# Altium schematic sheet be_mdv.SchDoc(be_mdv)
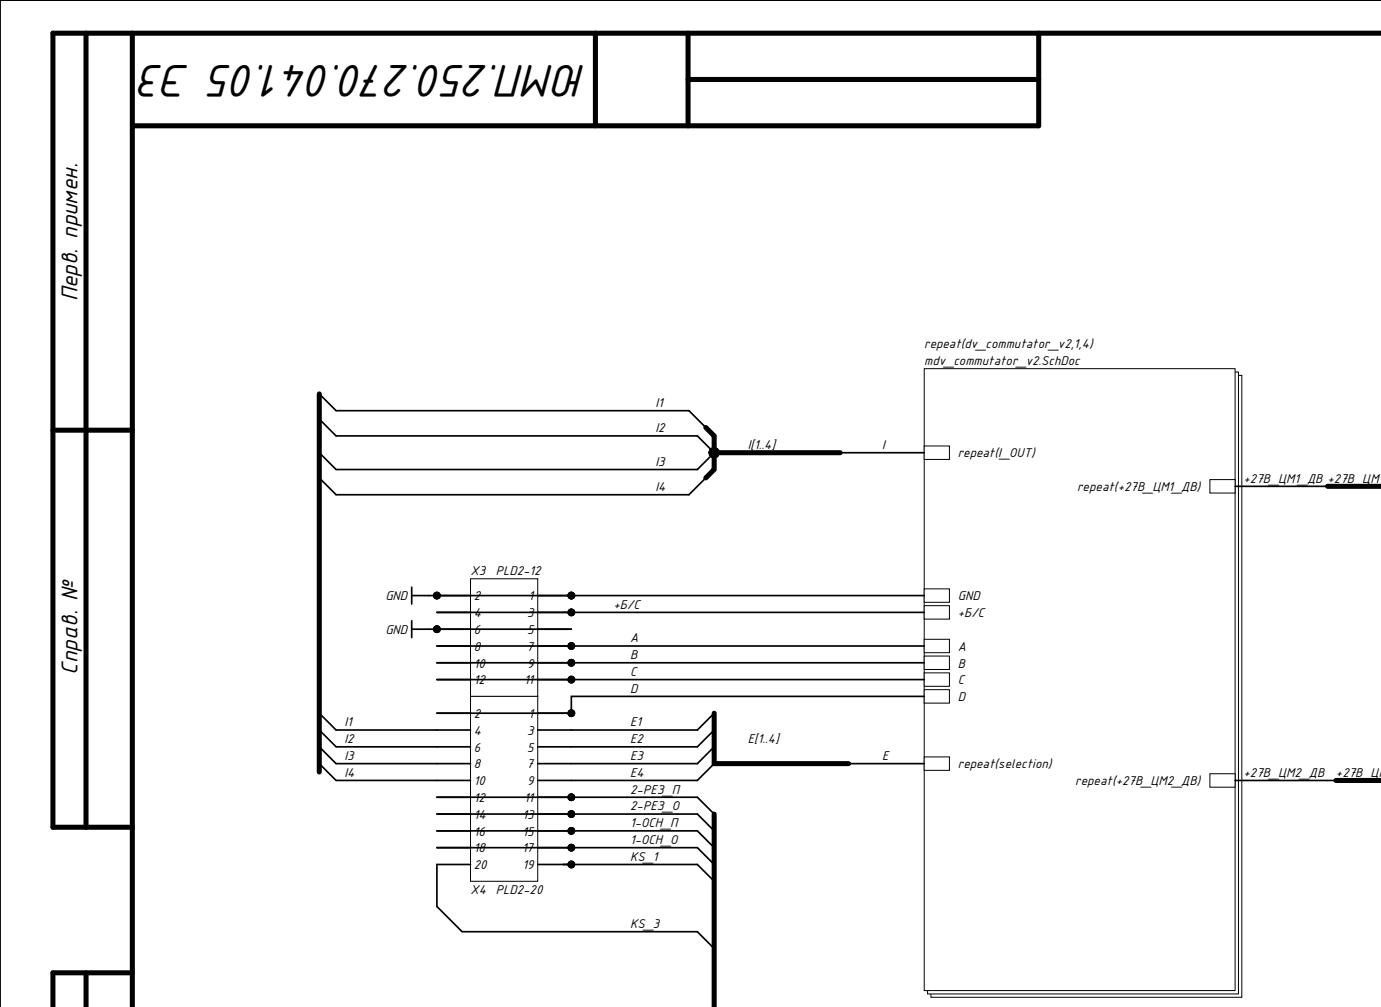
[diagram p0: be_mdv.SchDoc, top left]
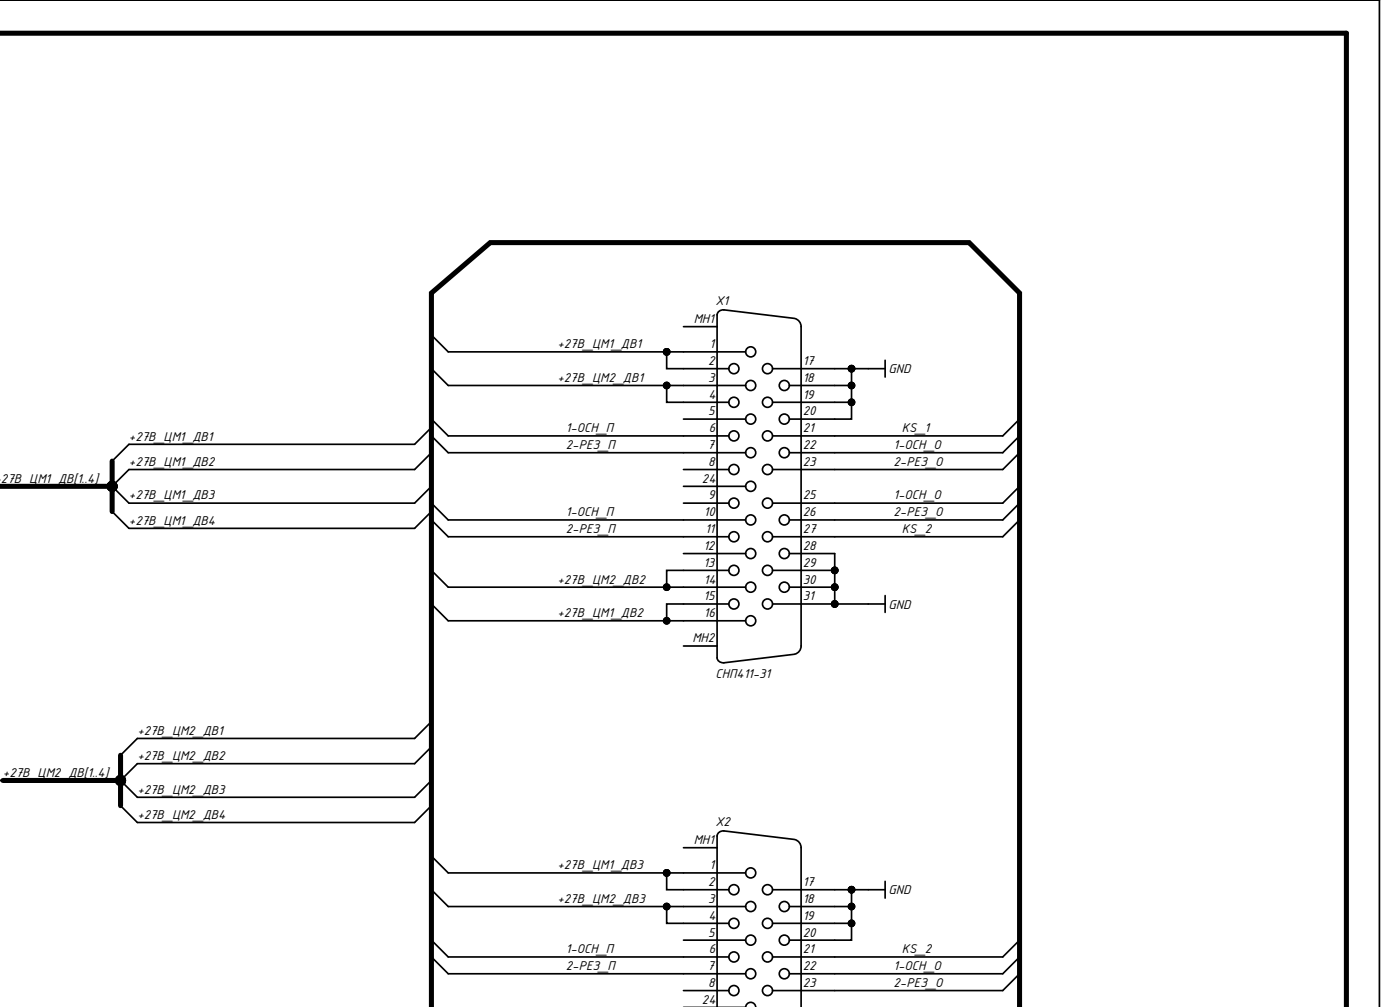
[diagram p1: be_mdv.SchDoc, top right]
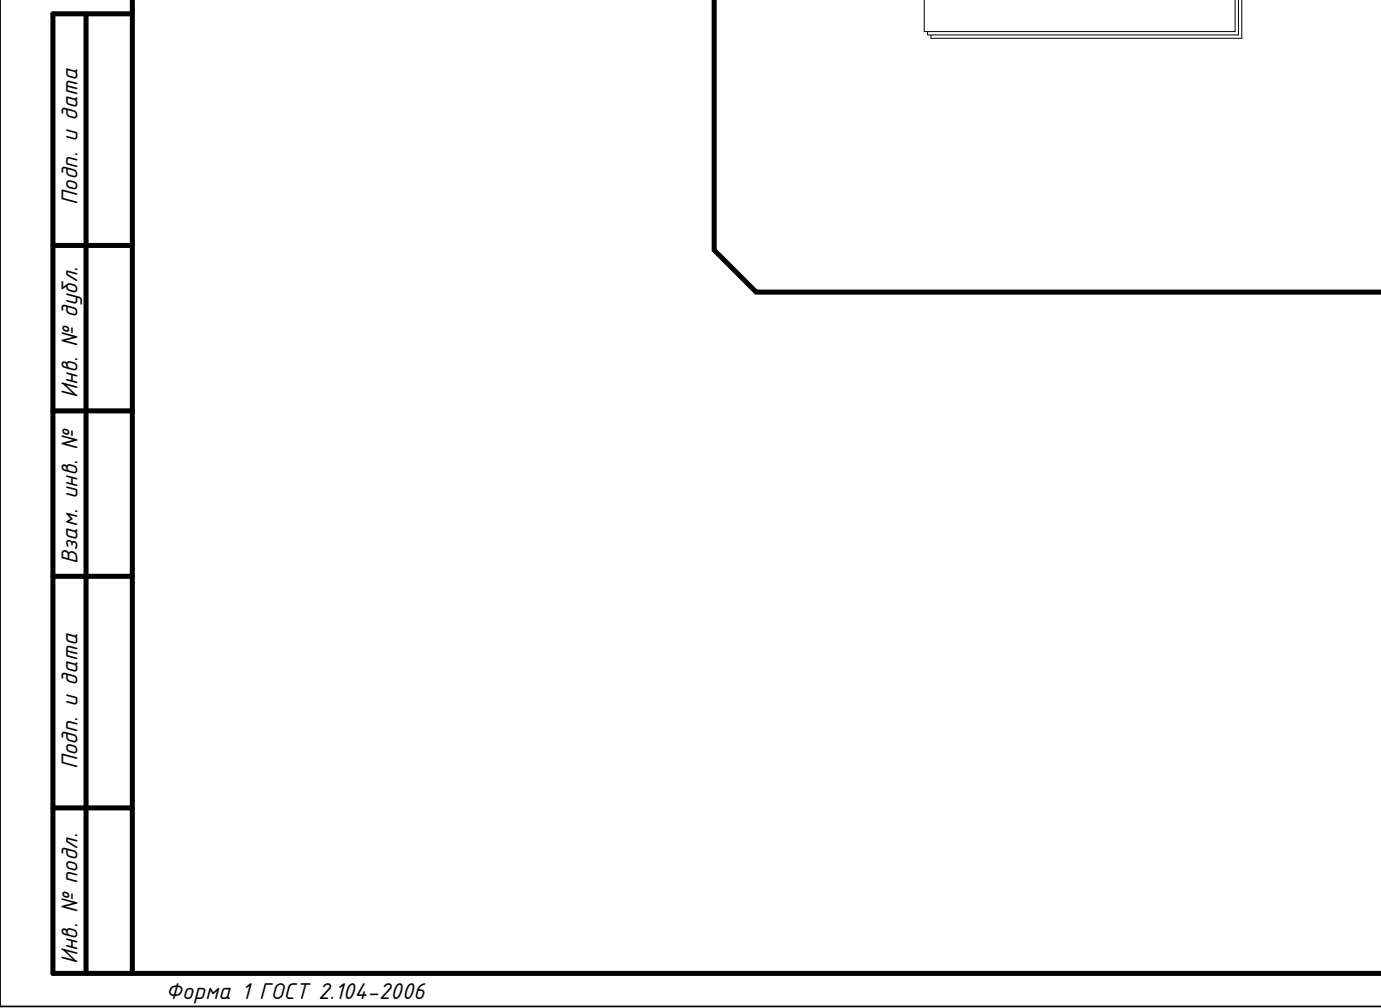
[diagram p2: be_mdv.SchDoc, bottom left]
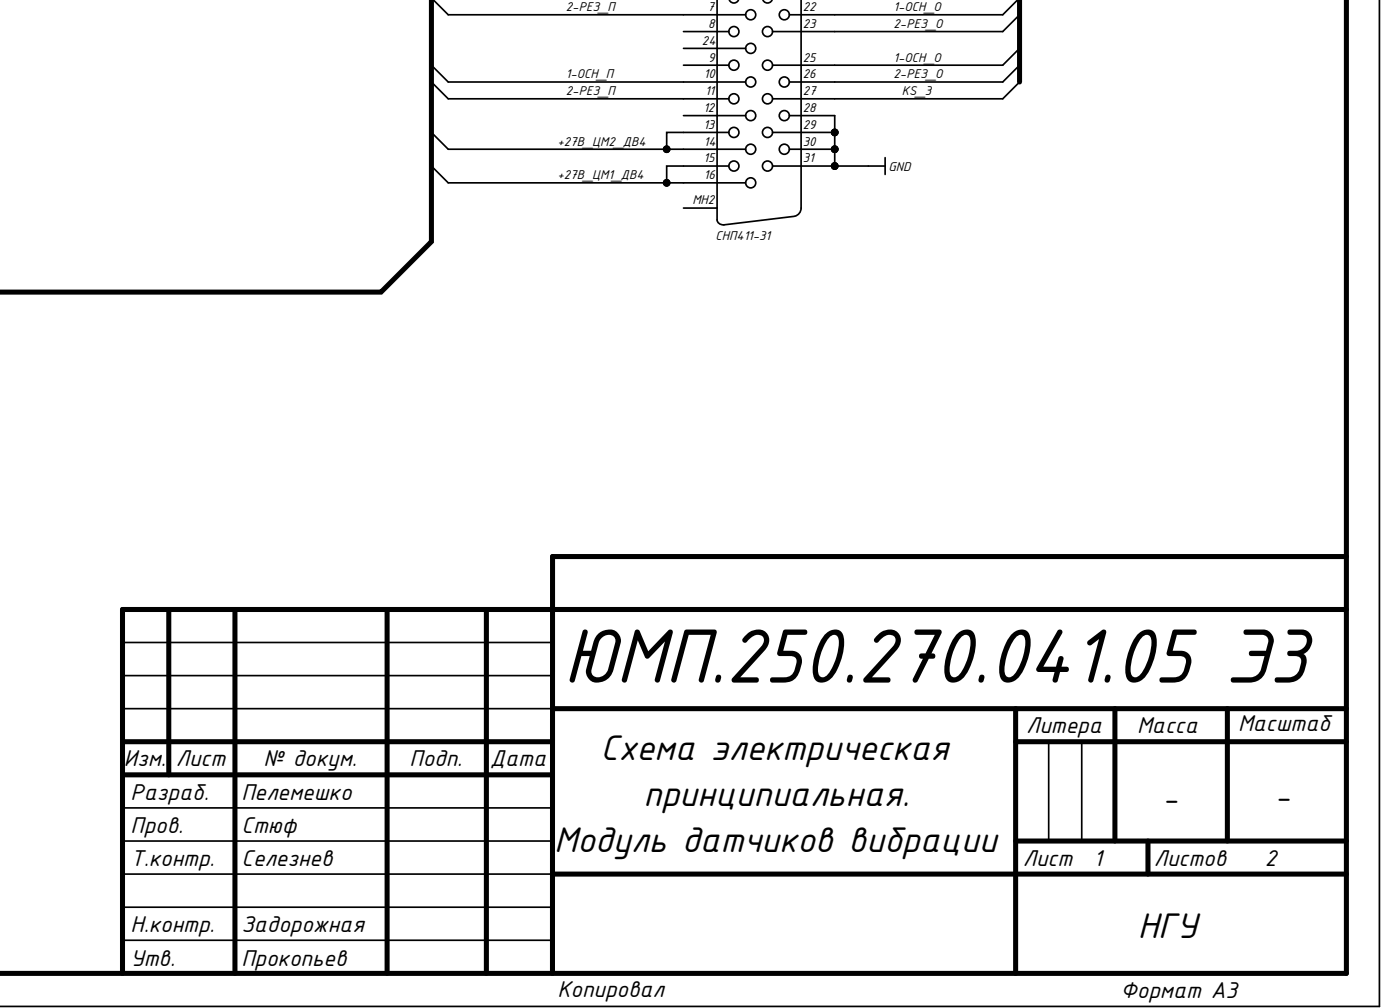
[diagram p3: be_mdv.SchDoc, bottom right]

## Parts
X1  SNP411-31  [SNP411-31]  (value not drawn)
X2  SNP411-31  [SNP411-31]  (value not drawn)
X3  Header 2X12  [PLD2-12]  (value not drawn)
X4  Header 2X20  [PLD2-20]  (value not drawn)

## Nets
GND: X1.17 X1.18 X1.19 X1.20 X1.28 X1.29 X1.30 X1.31 X2.17 X2.18 X2.19 X2.20 X2.28 X2.29 X2.30 X2.31 X3.1 X3.2 X3.5 X3.6
1-ОСН_О: X1.22 X1.25 X2.22 X2.25 X4.17 X4.18
1-ОСН_П: X1.6 X1.10 X2.6 X2.10 X4.15 X4.16
2-РЕЗ_О: X1.23 X1.26 X2.23 X2.26 X4.13 X4.14
2-РЕЗ_П: X1.7 X1.11 X2.7 X2.11 X4.11 X4.12
+27В_ЦМ1_ДВ1: X1.1 X1.2  [also labelled +27В_ЦМ1_ДВ]
+27В_ЦМ1_ДВ2: X1.15 X1.16  [also labelled +27В_ЦМ1_ДВ]
+27В_ЦМ1_ДВ3: X2.1 X2.2  [also labelled +27В_ЦМ1_ДВ]
+27В_ЦМ1_ДВ4: X2.15 X2.16  [also labelled +27В_ЦМ1_ДВ]
+27В_ЦМ2_ДВ1: X1.3 X1.4  [also labelled +27В_ЦМ2_ДВ]
+27В_ЦМ2_ДВ2: X1.13 X1.14  [also labelled +27В_ЦМ2_ДВ]
+27В_ЦМ2_ДВ3: X2.3 X2.4  [also labelled +27В_ЦМ2_ДВ]
+27В_ЦМ2_ДВ4: X2.13 X2.14  [also labelled +27В_ЦМ2_ДВ]
+Б/С: X3.3 X3.4
A: X3.7 X3.8
B: X3.9 X3.10
C: X3.11 X3.12
D: X4.1 X4.2
E1: X4.3  [also labelled E]
E2: X4.5  [also labelled E]
E3: X4.7  [also labelled E]
E4: X4.9  [also labelled E]
I1: X4.4  [also labelled I]
I2: X4.6  [also labelled I]
I3: X4.8  [also labelled I]
I4: X4.10  [also labelled I]
KS_1: X1.21 X4.19
KS_2: X1.27 X2.21
KS_3: X2.27 X4.20
NetX1_5: X1.5
NetX1_8: X1.8
NetX1_9: X1.9
NetX1_12: X1.12
NetX1_24: X1.24
NetX1_MH1: X1.MH1
NetX1_MH2: X1.MH2
NetX2_5: X2.5
NetX2_8: X2.8
NetX2_9: X2.9
NetX2_12: X2.12
NetX2_24: X2.24
NetX2_MH1: X2.MH1
NetX2_MH2: X2.MH2

## Library data of the parts
X1 X2 = СНП411-31: СНП411-31, распиновка со стороны платы; Назначение: Соединитель угловой на плату; Component Kind: Standard; Library Name: Connector.IntLib; Наименование: СНП411-31
X3 = Header 2X12: Header, 12-Pin, Dual row; Потребление, Вт: 0,0; Component Kind: Standard; Library Name: Connector.IntLib; Назначение: Header, 12-Pin, Dual row; Наименование: PLD2-12
X4 = Header 2X20: Header, 20-Pin, Dual row; Потребление, Вт: 0,0; Component Kind: Standard; Library Name: Connector.IntLib; Назначение: Header, 20-Pin, Dual row; Наименование: PLD2-20
